# Altium schematic sheet HEXIWEAR_MainBoard_v102.SchDoc
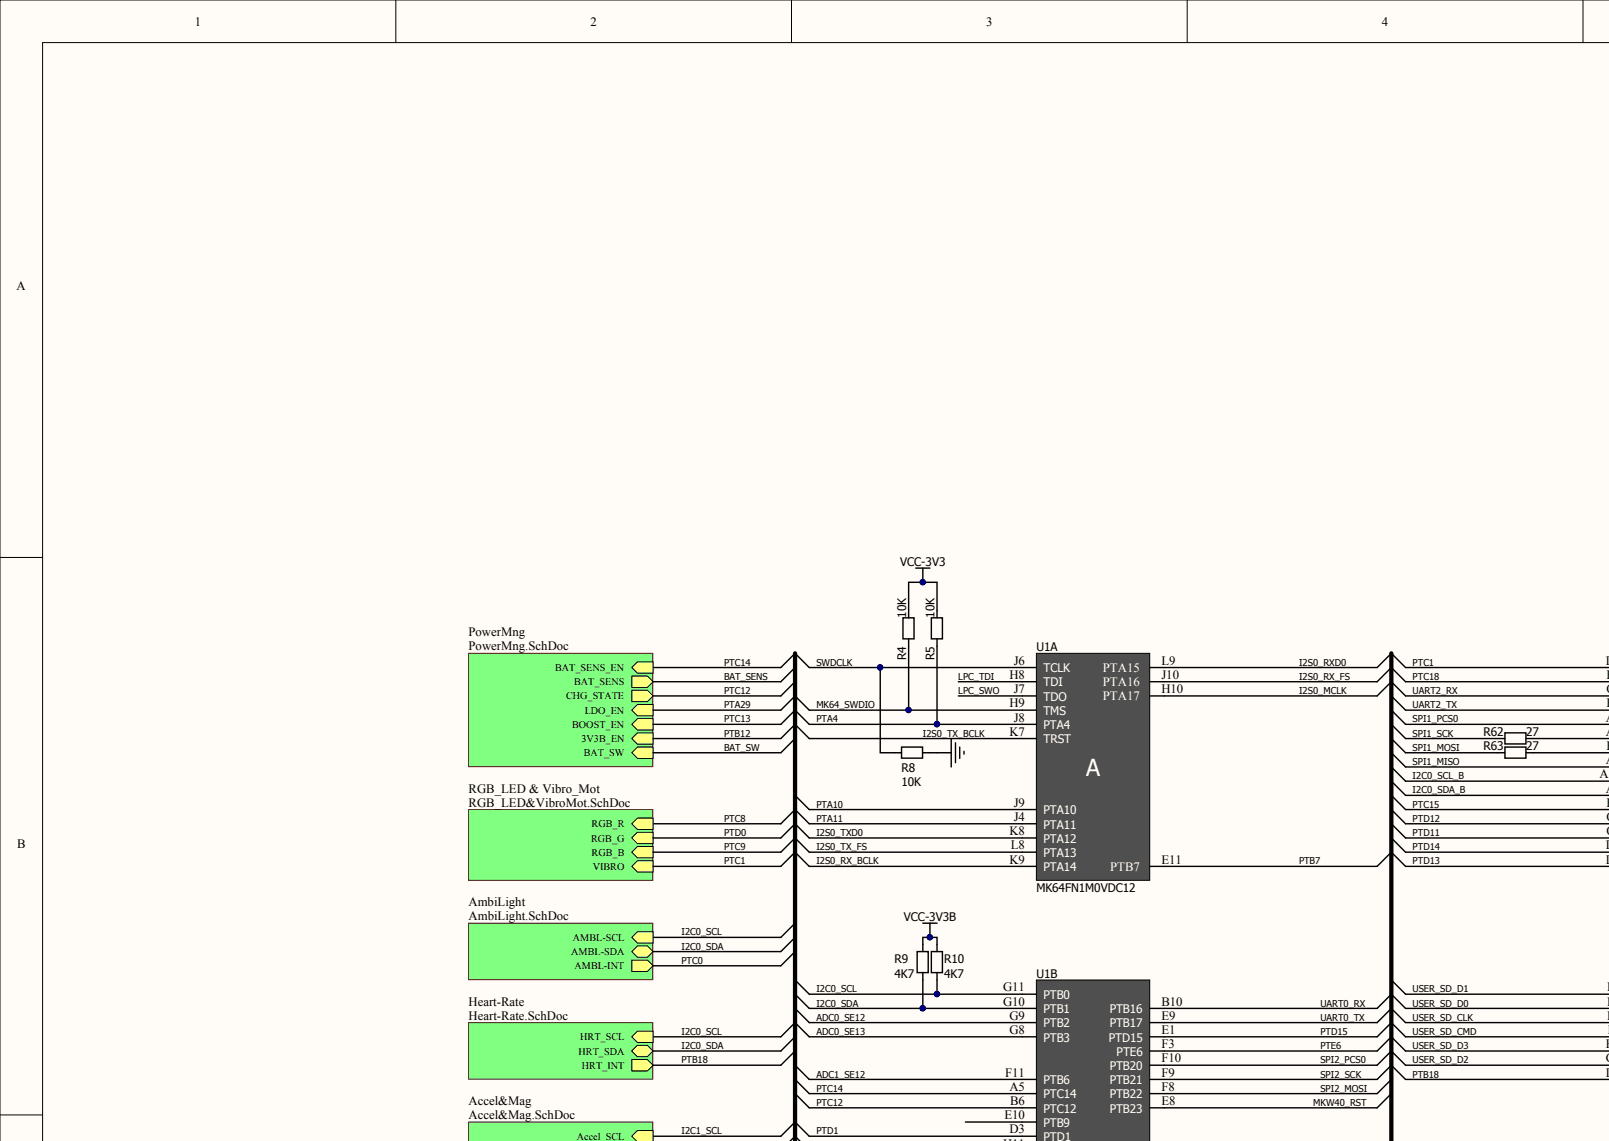
[diagram p0: HEXIWEAR_MainBoard_v102.SchDoc, top left]
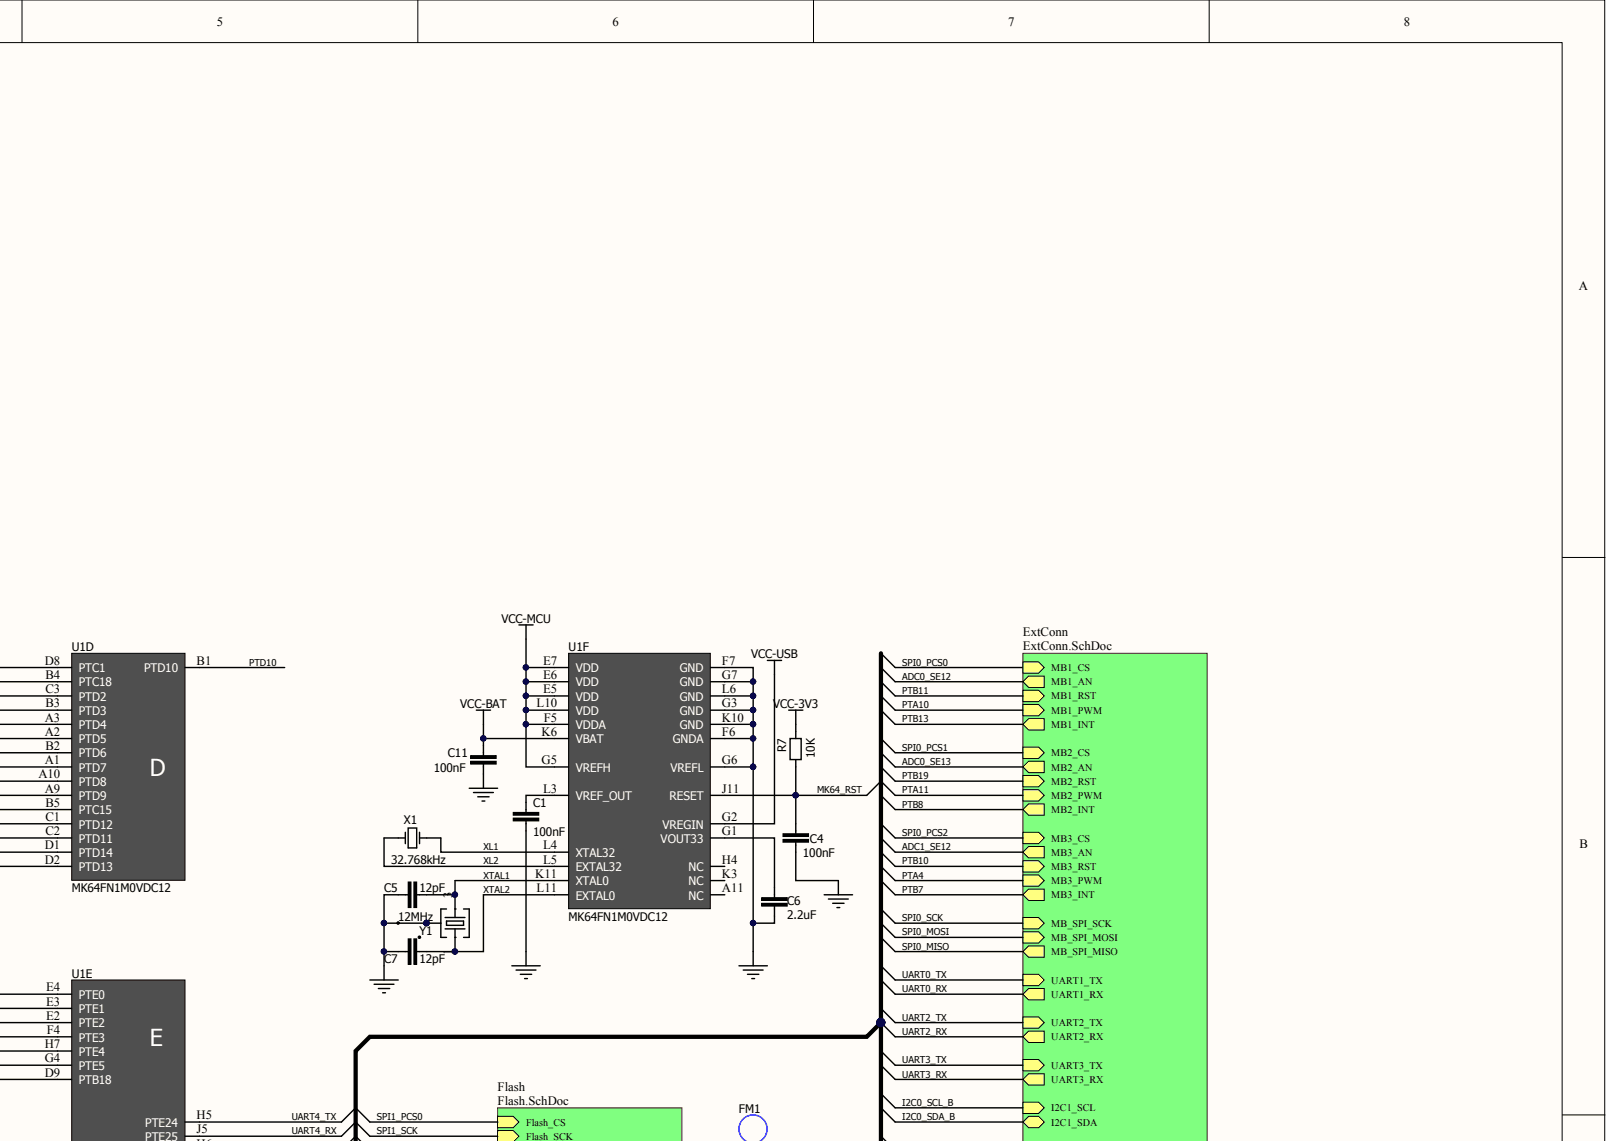
[diagram p1: HEXIWEAR_MainBoard_v102.SchDoc, top right]
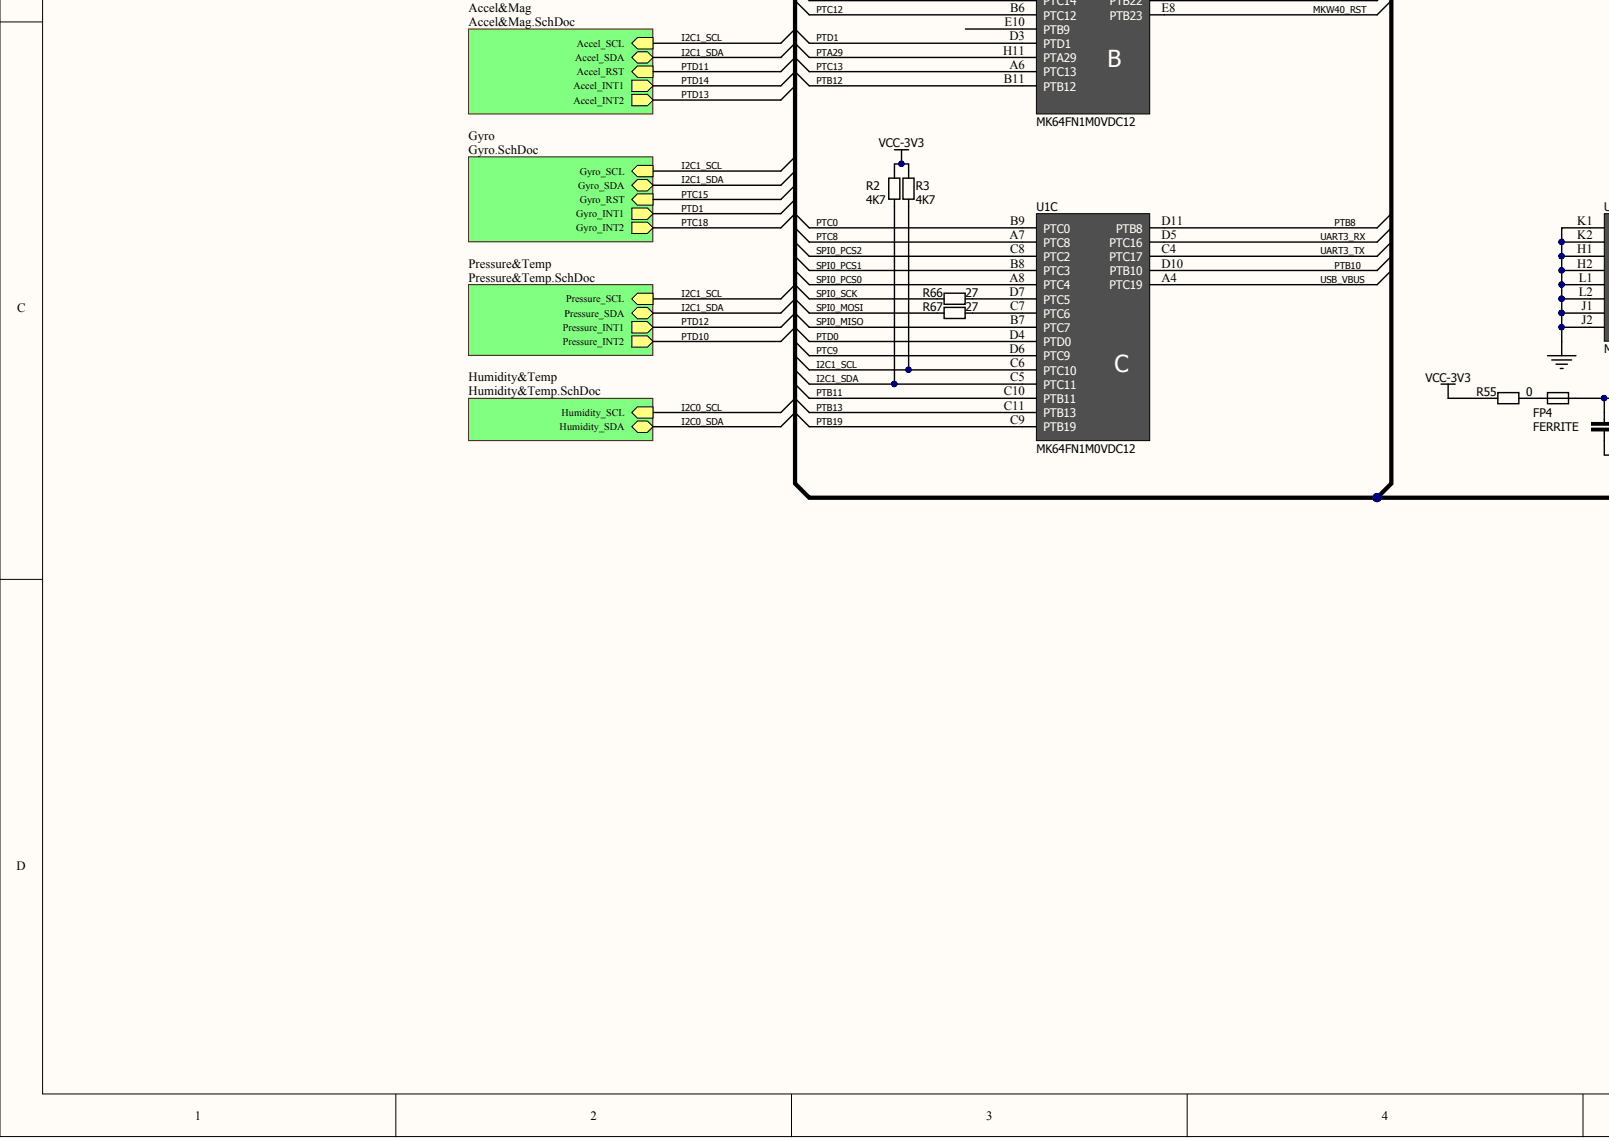
[diagram p2: HEXIWEAR_MainBoard_v102.SchDoc, bottom left]
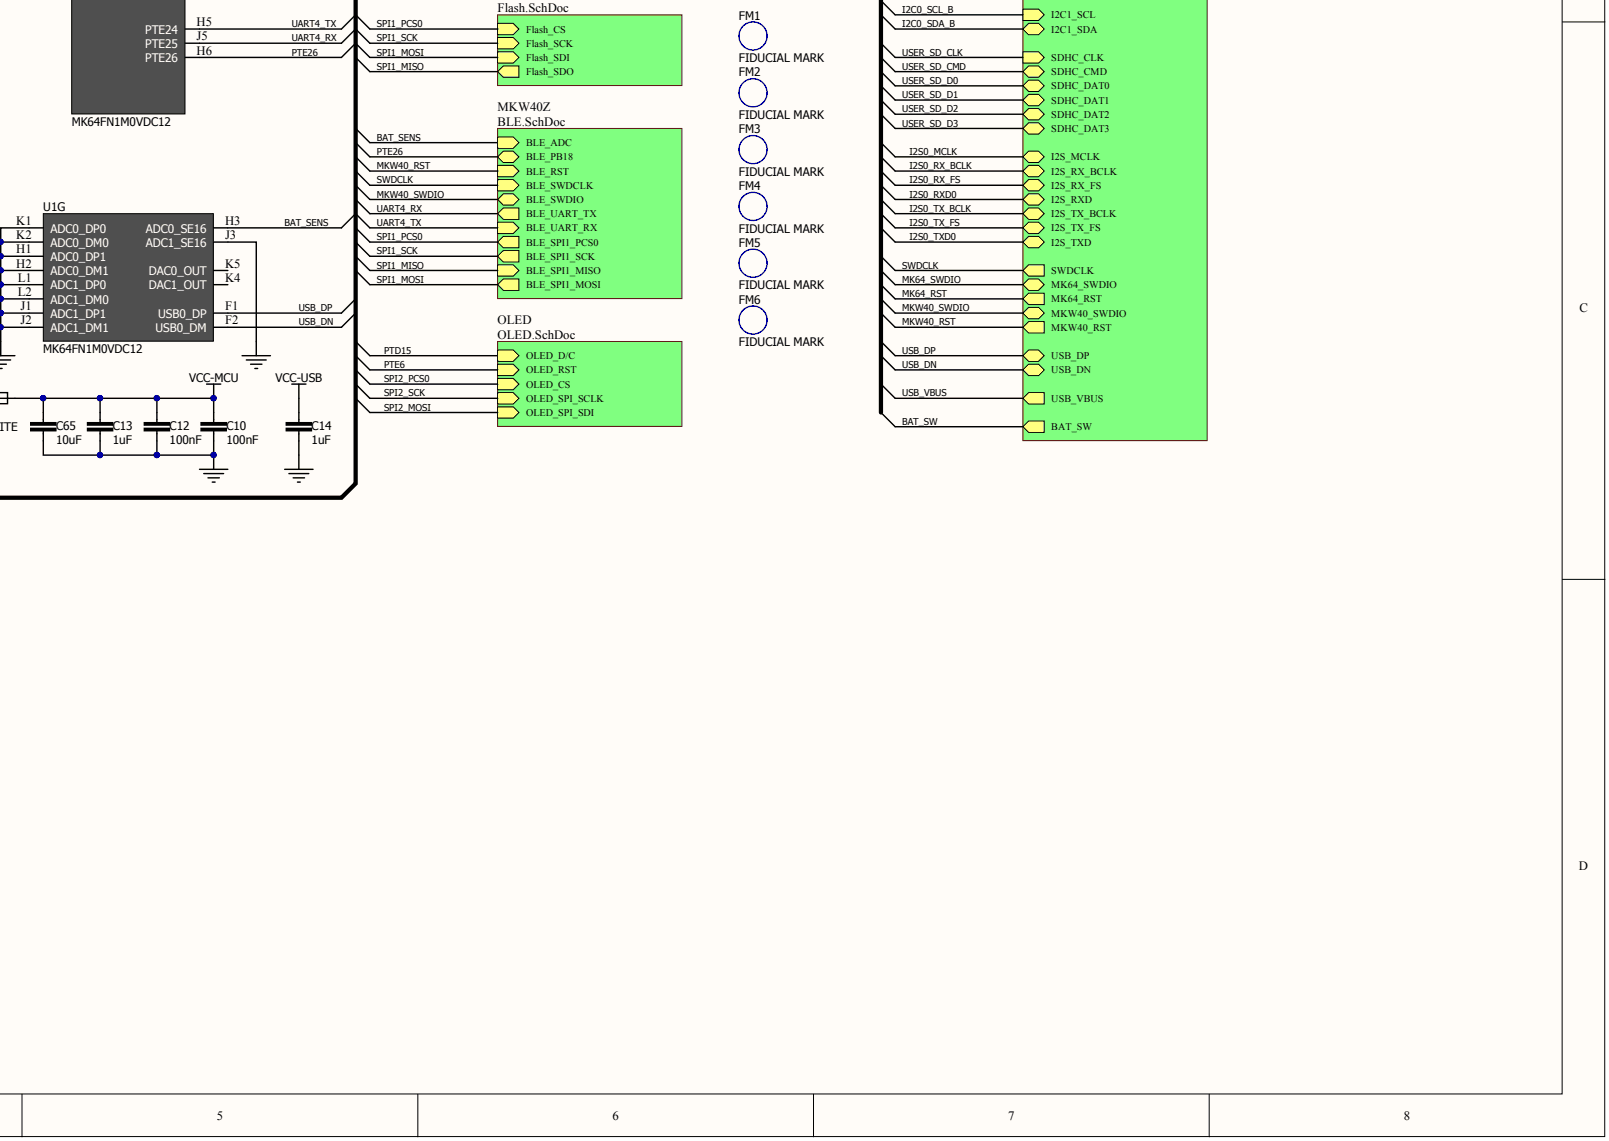
[diagram p3: HEXIWEAR_MainBoard_v102.SchDoc, bottom right]

## Parts
C1  100nF  [0402]
C4  100nF  [0402]
C5  12pF  [0402]
C6  2.2uF  [0603]
C7  12pF  [0402]
C10  100nF  [0402]
C11  100nF  [0402]
C12  100nF  [0402]
C13  1uF  [0402]
C14  1uF  [0402]
C65  10uF  [0603]
FM1  FIDUCIAL MARK  [FIDUCIAL MARK 0.6MM]  (no BOM)
FM2  FIDUCIAL MARK  [FIDUCIAL MARK 0.6MM]  (no BOM)
FM3  FIDUCIAL MARK  [FIDUCIAL MARK 0.6MM]  (no BOM)
FM4  FIDUCIAL MARK  [FIDUCIAL MARK 0.6MM]  (no BOM)
FM5  FIDUCIAL MARK  [FIDUCIAL MARK 0.6MM]  (no BOM)
FM6  FIDUCIAL MARK  [FIDUCIAL MARK 0.6MM]  (no BOM)
FP4  FERRITE  [0603]
R2  4K7  [0402]
R3  4K7  [0402]
R4  10K  [0402]
R5  10K  [0402]
R7  10K  [0402]
R8  10K  [0402]
R9  4K7  [0402]
R10  4K7  [0402]
R55  0  [0805]
R62  27  [0402]
R63  27  [0402]
R66  27  [0402]
R67  27  [0402]
U1  MK64FN1M0VDC12  [BGA121-0.65]  (parts A,B,C,D,E,F,G)
X1  32.768kHz  [1206_MINIMELF_COMBO]
Y1  12MHz  [ABM8G]

## Nets
GND: C1.2 C4.2 C5.2 C6.2 C7.2 C10.2 C11.2 C12.2 C13.2 C14.2 C65.2 R8.2 U1.F6(GNDA) U1.F7(GND) U1.G3(GND) U1.G6(VREFL) U1.G7(GND) U1.H1(ADC0_DP1) U1.H2(ADC0_DM1) U1.J1(ADC1_DP1) U1.J2(ADC1_DM1) U1.J3(ADC1_SE16) U1.K1(ADC0_DP0) U1.K2(ADC0_DM0) U1.K10(GND) U1.L1(ADC1_DP0) U1.L2(ADC1_DM0) U1.L6(GND) Y1.2 Y1.4
VCC-3V3: R2.2 R3.2 R4.1 R5.1 R7.1 R55.1
VCC-3V3B: R9.2 R10.2
VCC-BAT: C11.1 U1.K6(VBAT)
VCC-MCU: C10.1 C12.1 C13.1 C65.1 FP4.2 U1.E5(VDD) U1.E6(VDD) U1.E7(VDD) U1.F5(VDDA) U1.G5(VREFH) U1.L10(VDD)
VCC-USB: C14.1 U1.G2(VREGIN)
ADC0_SE12: U1.G9(PTB2)
ADC0_SE13: U1.G8(PTB3)
ADC1_SE12: U1.F11(PTB6)
BAT_SENS: U1.H3(ADC0_SE16)
I2C0_SCL: R10.1 U1.G11(PTB0)
I2C0_SCL_B: U1.A10(PTD8)
I2C0_SDA: R9.1 U1.G10(PTB1)
I2C0_SDA_B: U1.A9(PTD9)
I2C1_SCL: R3.1 U1.C6(PTC10)
I2C1_SDA: R2.1 U1.C5(PTC11)
I2S0_MCLK: U1.H10(PTA17)
I2S0_RX_BCLK: U1.K9(PTA14)
I2S0_RX_FS: U1.J10(PTA16)
I2S0_RXD0: U1.L9(PTA15)
I2S0_TX_BCLK: U1.K7(TRST)
I2S0_TX_FS: U1.L8(PTA13)
I2S0_TXD0: U1.K8(PTA12)
LPC_SWO: U1.J7(TDO)
LPC_TDI: U1.H8(TDI)
MK64_RST: C4.1 R7.2 U1.J11(RESET)
MK64_SWDIO: R4.2 U1.H9(TMS)
MKW40_RST: U1.E8(PTB23)
PTA4: R5.2 U1.J8(PTA4)
PTA10: U1.J9(PTA10)
PTA11: U1.J4(PTA11)
PTA29: U1.H11(PTA29)
PTB7: U1.E11(PTB7)
PTB8: U1.D11(PTB8)
PTB10: U1.D10(PTB10)
PTB11: U1.C10(PTB11)
PTB12: U1.B11(PTB12)
PTB13: U1.C11(PTB13)
PTB18: U1.D9(PTB18)
PTB19: U1.C9(PTB19)
PTC0: U1.B9(PTC0)
PTC1: U1.D8(PTC1)
PTC8: U1.A7(PTC8)
PTC9: U1.D6(PTC9)
PTC12: U1.B6(PTC12)
PTC13: U1.A6(PTC13)
PTC14: U1.A5(PTC14)
PTC15: U1.B5(PTC15)
PTC18: U1.B4(PTC18)
PTD0: U1.D4(PTD0)
PTD1: U1.D3(PTD1)
PTD10: U1.B1(PTD10)
PTD11: U1.C2(PTD11)
PTD12: U1.C1(PTD12)
PTD13: U1.D2(PTD13)
PTD14: U1.D1(PTD14)
PTD15: U1.E1(PTD15)
PTE6: U1.F3(PTE6)
PTE26: U1.H6(PTE26)
SPI0_MISO: U1.B7(PTC7)
SPI0_MOSI: R67.1
SPI0_PCS0: U1.A8(PTC4)
SPI0_PCS1: U1.B8(PTC3)
SPI0_PCS2: U1.C8(PTC2)
SPI0_SCK: R66.1
SPI1_MISO: U1.A1(PTD7)
SPI1_MOSI: R63.1
SPI1_PCS0: U1.A3(PTD4)
SPI1_SCK: R62.1
SPI2_MOSI: U1.F8(PTB22)
SPI2_PCS0: U1.F10(PTB20)
SPI2_SCK: U1.F9(PTB21)
SWDCLK: R8.1 U1.J6(TCLK)
UART0_RX: U1.B10(PTB16)
UART0_TX: U1.E9(PTB17)
UART2_RX: U1.C3(PTD2)
UART2_TX: U1.B3(PTD3)
UART3_RX: U1.D5(PTC16)
UART3_TX: U1.C4(PTC17)
UART4_RX: U1.J5(PTE25)
UART4_TX: U1.H5(PTE24)
USB_DN: U1.F2(USB0_DM)
USB_DP: U1.F1(USB0_DP)
USB_VBUS: U1.A4(PTC19)
USER_SD_CLK: U1.E2(PTE2)
USER_SD_CMD: U1.F4(PTE3)
USER_SD_D0: U1.E3(PTE1)
USER_SD_D1: U1.E4(PTE0)
USER_SD_D2: U1.G4(PTE5)
USER_SD_D3: U1.H7(PTE4)
XL1: U1.L4(XTAL32) X1.1
XL2: U1.L5(EXTAL32) X1.2
XTAL1: C5.1 U1.K11(XTAL0) Y1.3
XTAL2: C7.1 U1.L11(EXTAL0) Y1.1
NetC1_1: C1.1 U1.L3(VREF_OUT)
NetC6_1: C6.1 U1.G1(VOUT33)
NetFP4_1: FP4.1 R55.2
NetR62_2: R62.2 U1.A2(PTD5)
NetR63_2: R63.2 U1.B2(PTD6)
NetR66_2: R66.2 U1.D7(PTC5)
NetR67_2: R67.2 U1.C7(PTC6)
NetU1_A11: U1.A11(NC)
NetU1_E10: U1.E10(PTB9)
NetU1_H4: U1.H4(NC)
NetU1_K3: U1.K3(NC)
NetU1_K4: U1.K4(DAC1_OUT)
NetU1_K5: U1.K5(DAC0_OUT)
BAT_SW:
MKW40_SWDIO:
N00241:

## Library data of the parts
C1 C4-C7 C10-C14 C65 = CAP NP: Capacitor; Component Kind: Standard; SMD: Yes; Library Name: WunderBar_v102.SCHLIB
R2-R5 R7-R10 = RES: Resistor; Component Kind: Standard; SMD: Yes; Library Name: WunderBar_v102.SCHLIB
R55 R62 R63 R66 R67 = RES: Component Kind: Standard; SMD: Yes; Library Name: Stellaris_JTAG_v100.SCHLIB
X1 = CRYSTAL: Crystal Oscillator; Component Kind: Standard; SMD: Yes; Library Name: WunderBar_v102.SCHLIB
Y1 = CRYSTAL_WITH_GROUNDABM8G: CX2520DB16000D0GEJCC; 1: 1; 2: 2; Component Kind: Standard; SMD: Yes; Library Name: WunderBar_v102.SCHLIB
FM1-FM6 = FIDUCIAL MARK: Description: Fiducial Mark; SMD: Fiducial; Library Name: WunderBar_v101.SCHLIB
FP4 = FERRITE: Component Kind: Standard; SMD: Yes; Library Name: Stellaris_JTAG_v100.SCHLIB
U1 = MK64FN1M0VDC12: Component Kind: Standard; SMD: Yes; Library Name: WunderBar_v102.SCHLIB
